# Altium schematic sheet SCM20100E-Eth.SchDoc(SCM20100E-Eth)
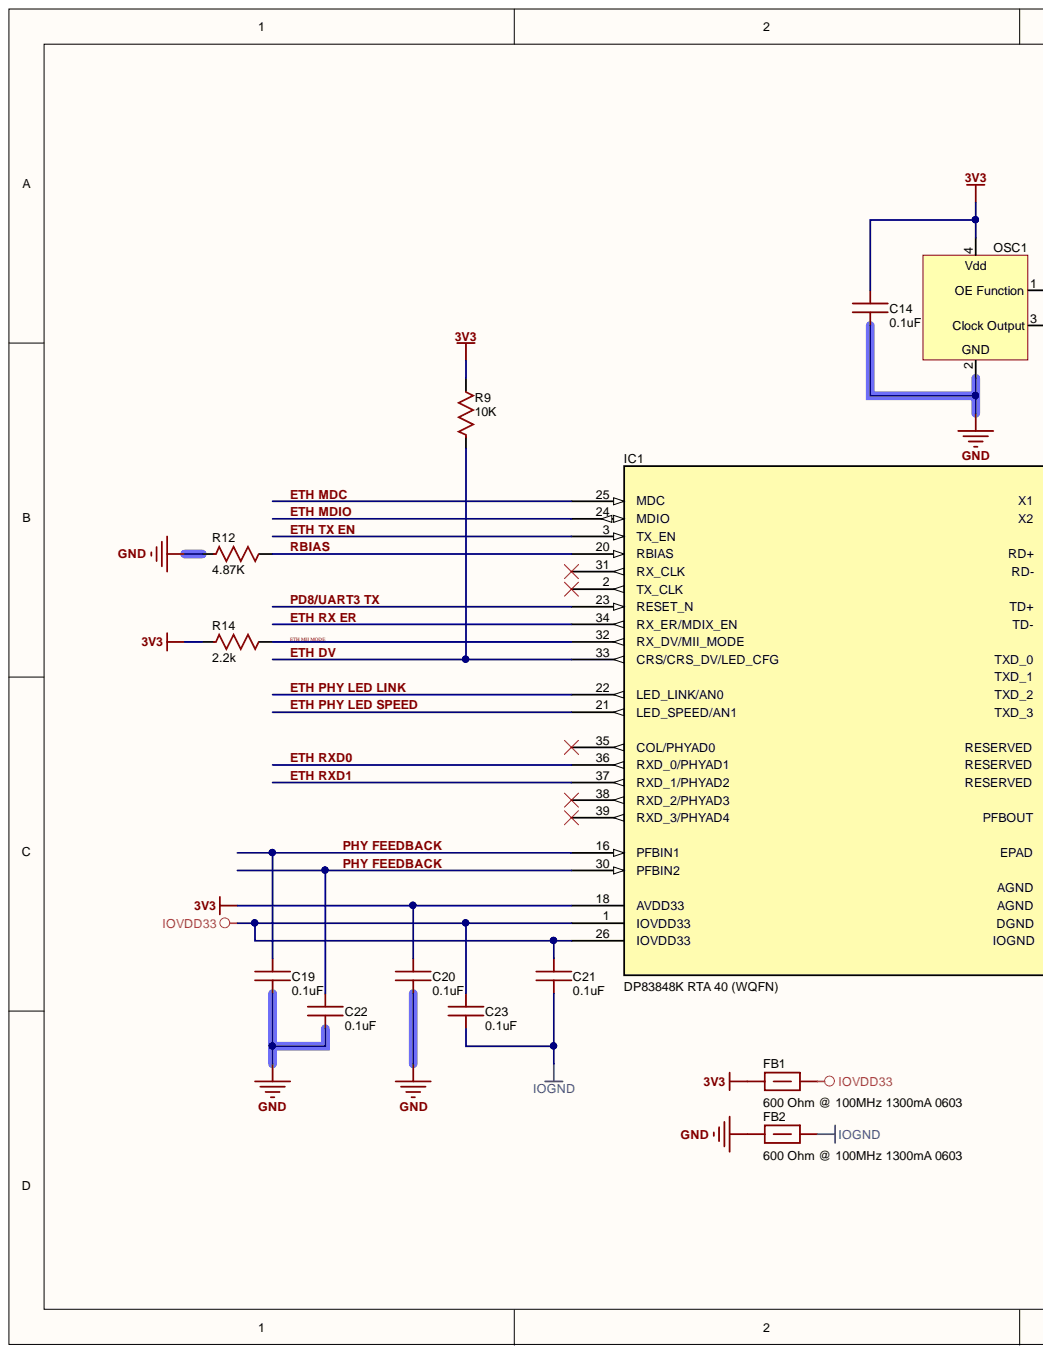
[diagram p0: SCM20100E-Eth.SchDoc, left]
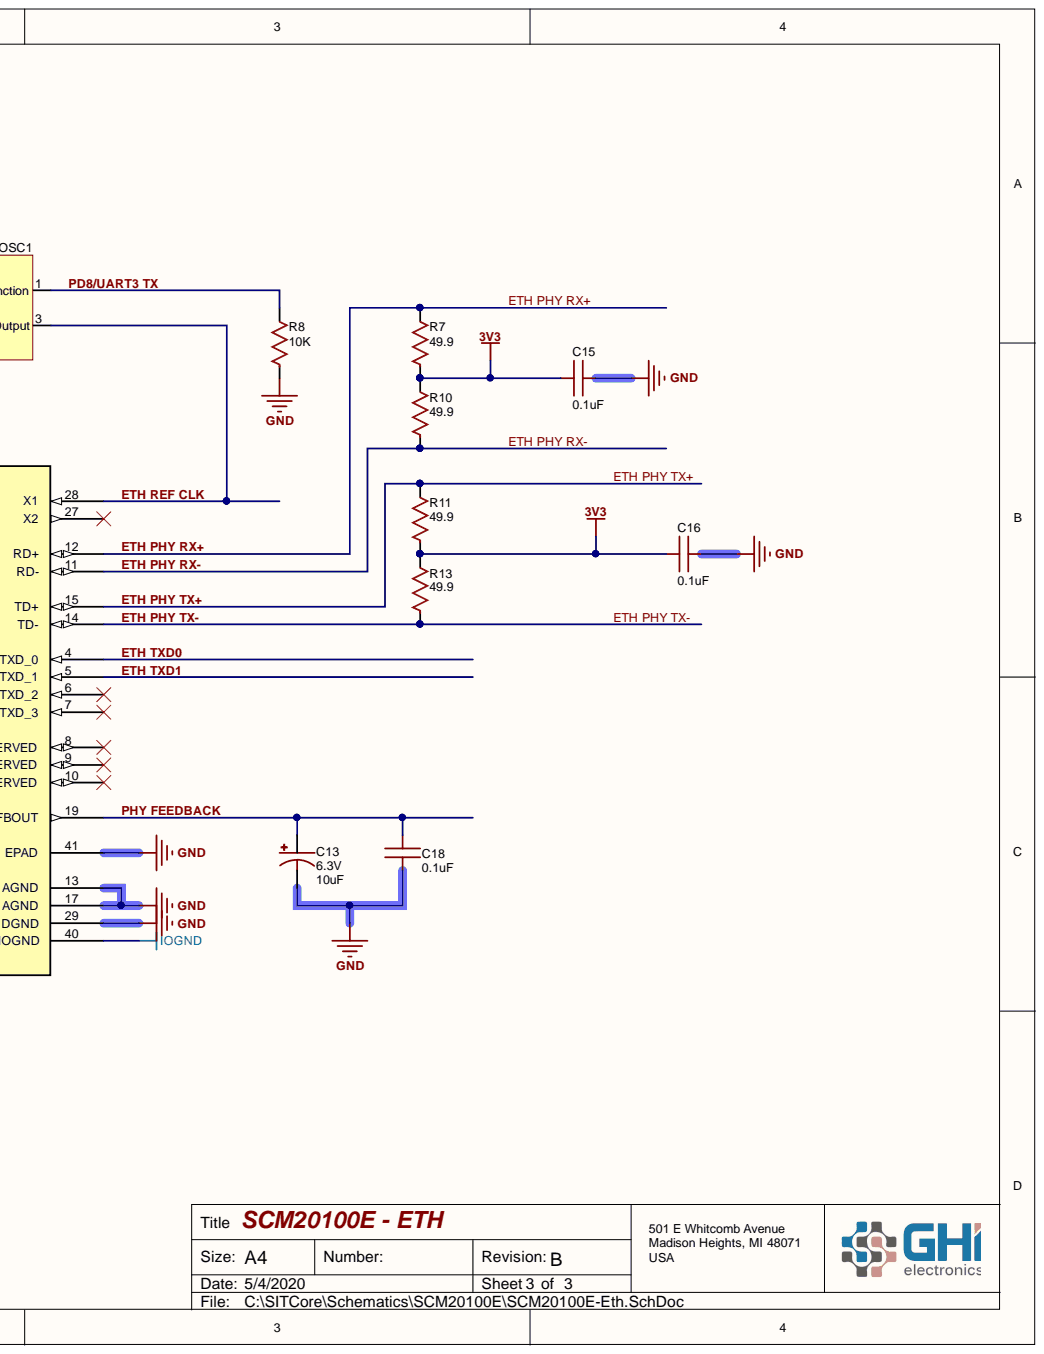
[diagram p1: SCM20100E-Eth.SchDoc, right]

## Parts
C13  value 10uF  Voltage: 6.3V  [CASE-R 0805 (2012)]  (hidden comment: CASE-R 0805 (2012) Tant 10uF)
C14  value 0.1uF  [C0402]  (hidden comment: 0402 Cap 0.1uF)
C15  value 0.1uF  [C0402]  (hidden comment: 0402 Cap 0.1uF)
C16  value 0.1uF  [C0402]  (hidden comment: 0402 Cap 0.1uF)
C18  value 0.1uF  [C0402]  (hidden comment: 0402 Cap 0.1uF)
C19  value 0.1uF  [C0402]  (hidden comment: 0402 Cap 0.1uF)
C20  value 0.1uF  [C0402]  (hidden comment: 0402 Cap 0.1uF)
C21  value 0.1uF  [C0402]  (hidden comment: 0402 Cap 0.1uF)
C22  value 0.1uF  [C0402]  (hidden comment: 0402 Cap 0.1uF)
C23  value 0.1uF  [C0402]  (hidden comment: 0402 Cap 0.1uF)
FB1  600 Ohm @ 100MHz 1300mA 0603  [R0603 (Metric 1608)]
FB2  600 Ohm @ 100MHz 1300mA 0603  [R0603 (Metric 1608)]
IC1  DP83848K RTA 40 (WQFN)  value DP83848K RTA 40  [WQFN 40 (TI-RTA-40)]
OSC1  Oscillator 50 MHz 4 Pad 3.2mm x 2.5mm  [Crystal 3.2mm x 2.5mm]  (value not drawn)
R7  value 49.9  [R0402]  (hidden comment: 0402 Res 49.9)
R8  value 10K  [R0402]  (hidden comment: 0402 Res 10K)
R9  value 10K  [R0402]  (hidden comment: 0402 Res 10K)
R10  value 49.9  [R0402]  (hidden comment: 0402 Res 49.9)
R11  value 49.9  [R0402]  (hidden comment: 0402 Res 49.9)
R12  value 4.87K  [R0402]  (hidden comment: 0402 Res 4.87K)
R13  value 49.9  [R0402]  (hidden comment: 0402 Res 49.9)
R14  value 2.2k  [R0402]  (hidden comment: 0402 Res 2.2k)

## Nets
3V3: C14.2 C15.1 C16.1 C20.2 FB1.2 IC1.18(AVDD33) OSC1.4 R7.1 R9.2 R10.2 R11.1 R13.2 R14.1
GND: C13.2 C14.1 C15.2 C16.2 C18.1 C19.1 C20.1 C22.1 FB2.2 IC1.13(AGND) IC1.17(AGND) IC1.29(DGND) IC1.41(EPAD) OSC1.2 R8.1 R12.1
IOGND: C21.1 C23.1 FB2.1 IC1.40(IOGND)
IOVDD33: C21.2 C23.2 FB1.1 IC1.1(IOVDD33) IC1.26(IOVDD33)
ETH DV: IC1.33(CRS/CRS_DV/LED_CFG) R9.1
ETH MDC: IC1.25(MDC)
ETH MDIO: IC1.24(MDIO)
ETH MII MODE: IC1.32(RX_DV/MII_MODE) R14.2
ETH PHY LED LINK: IC1.22(LED_LINK/AN0)
ETH PHY LED SPEED: IC1.21(LED_SPEED/AN1)
ETH PHY RX+: IC1.12(RD+) R7.2
ETH PHY RX-: IC1.11(RD-) R10.1
ETH PHY TX+: IC1.15(TD+) R11.2
ETH PHY TX-: IC1.14(TD-) R13.1
ETH REF CLK: IC1.28(X1) OSC1.3(Clock_Output)
ETH RX ER: IC1.34(RX_ER/MDIX_EN)
ETH RXD0: IC1.36(RXD_0/PHYAD1)
ETH RXD1: IC1.37(RXD_1/PHYAD2)
ETH TX EN: IC1.3(TX_EN)
ETH TXD0: IC1.4(TXD_0)
ETH TXD1: IC1.5(TXD_1)
PD8/UART3 TX: IC1.23(RESET_N) OSC1.1(OE_Function) R8.2
PHY FEEDBACK: C13.1 C18.2 C19.2 C22.2 IC1.16(PFBIN1) IC1.19(PFBOUT) IC1.30(PFBIN2)
RBIAS: IC1.20(RBIAS) R12.2

Not connected: IC1 2(TX_CLK) 6(TXD_2) 7(TXD_3) 8(RESERVED) 9(RESERVED) 10(RESERVED) 27(X2) 31(RX_CLK) 35(COL/PHYAD0) 38(RXD_2/PHYAD3) 39(RXD_3/PHYAD4)

## Library data of the parts
C13 = CASE-R 0805 (2012) Tant 10uF: 10uF ±10% 6.3V 6 Ω @ 100kHz -55℃ ~ +125℃ CASE-R_2012 Tantalum Capacitors RoHS; Mfr.Part #: TAJR106K006RNJ; Manufacturer: AVX; Tolerance: ±10%; Package: CASE-N_2012; LCSC Part #: C132274; Digikey: 478-3273-2-ND; Component Kind: Standard; Category: Tantalum; Capacitance: 10uF; Library Name: GHI-Passives.SchLib
C14-C16 C18-C23 = 0402 Cap 0.1uF: 0.1uF ±10% 16V X7R 0402 Multilayer Ceramic Capacitors MLCC - SMD/SMT RoHS; Mfr.Part #: CC0402KRX7R7BB104; Voltage: 16V; Tolerance: ±10%; Package: 0402; LCSC Part #: C60474; Component Kind: Standard; Category: Ceramic; Capacitance: 0.1uF; Library Name: GHI-Passives.SchLib
FB1 FB2 = 600 Ohm @ 100MHz 1300mA 0603 (Metric 1608): Ferrite Bead 600 Ohm @ 100MHz 1300mA 0603 (Metric 1608); Manufacturer Part Number: BLM18KG601SN1D; GHI Part Number: x; Rated Current (mA @ 125C): 1000; Rated Current (mA @ 85C): 1300; Impedance @ 100MHz: 600+-25%; DC Resistance: 150 mOhm; Package: 0603; Library Name: GHI Basic Components.SchLib; Component Type: Ferrite Bead; Component Kind: Standard
IC1 = DP83848K RTA 40 (WQFN): TI DP83848K 10/100 Ethernet PHY; Manufacturer Part Number: dp8384k_rta_40; Vendor: Texas Instruments; Type: Type; RefDes: RefDes; Component Kind: Standard; Library Name: GHI IC.SchLib
OSC1 = 50.0000MHz 4 Pad Oscillator 3.2mm x 2.5mm: Oscillator 4 Pad 3.2mm x 2.5mm 50 MHz; Manufacturer Part Number: 6034050000M1503033451; GHI Part Number: EPC-Q50MHZ-EMX; Supply Voltage: 3.3V; Output Frequency: 50.000MHz; Frequency Stability: 50ppm; Fan Out Type: TTL/CMOS; Operating Temperature Range: -40 C to +85 C; Library Name: GHI.SchLib; Component Kind: Standard
R7 R10 R11 R13 = 0402 Res 49.9: 49.9 ±1% 1/16W ±100ppm/℃ 0402 Chip Resistor - Surface Mount RoHS; Mfr.Part #: RC0402FR-0749R9L; Manufacturer: YAGEO; Voltage Rating: *V; Resistance Tolerance: ±1%; Resistance: 49.9; Power Rating: 1/16W; Package: 0402; LCSC Part #: C87044; Component Kind: Standard; Library Name: GHI-Passives.SchLib
R8 R9 = 0402 Res 10K: 10K ±1% 1/16W ±100ppm/℃ 0402 Chip Resistor - Surface Mount RoHS; Mfr.Part #: RC0402FR-0710KL; Manufacturer: YAGEO; Voltage Rating: *V; Resistance Tolerance: ±1%; Resistance: 10K; Power Rating: 1/16W; Package: 0402; LCSC Part #: C60490; Component Kind: Standard; Library Name: GHI-Passives.SchLib
R12 = 0402 Res 4.87K: 4.87K ±1% 1/16W ±100ppm/℃ 0402 Chip Resistor - Surface Mount RoHS; Mfr.Part #: RC0402FR-074K87L; Manufacturer: YAGEO; Voltage Rating: *V; Resistance Tolerance: ±1%; Resistance: 4.87K; Power Rating: 1/16W; Package: 0402; LCSC Part #: C276272; Component Kind: Standard; Library Name: GHI-Passives.SchLib
R14 = 0402 Res 2.2k: 2.2K ±1% 1/16W ±100ppm/℃ 0402 Chip Resistor - Surface Mount RoHS; Mfr.Part #: RC0402FR-072K2L; Manufacturer: YAGEO; Voltage Rating: *V; Resistance Tolerance: ±1%; Resistance: 2.2k; Power Rating: 1/16W; Package: 0402; LCSC Part #: C114762; Component Kind: Standard; Library Name: GHI-Passives.SchLib
